# Altium schematic sheet 8.RELAY.SchDoc
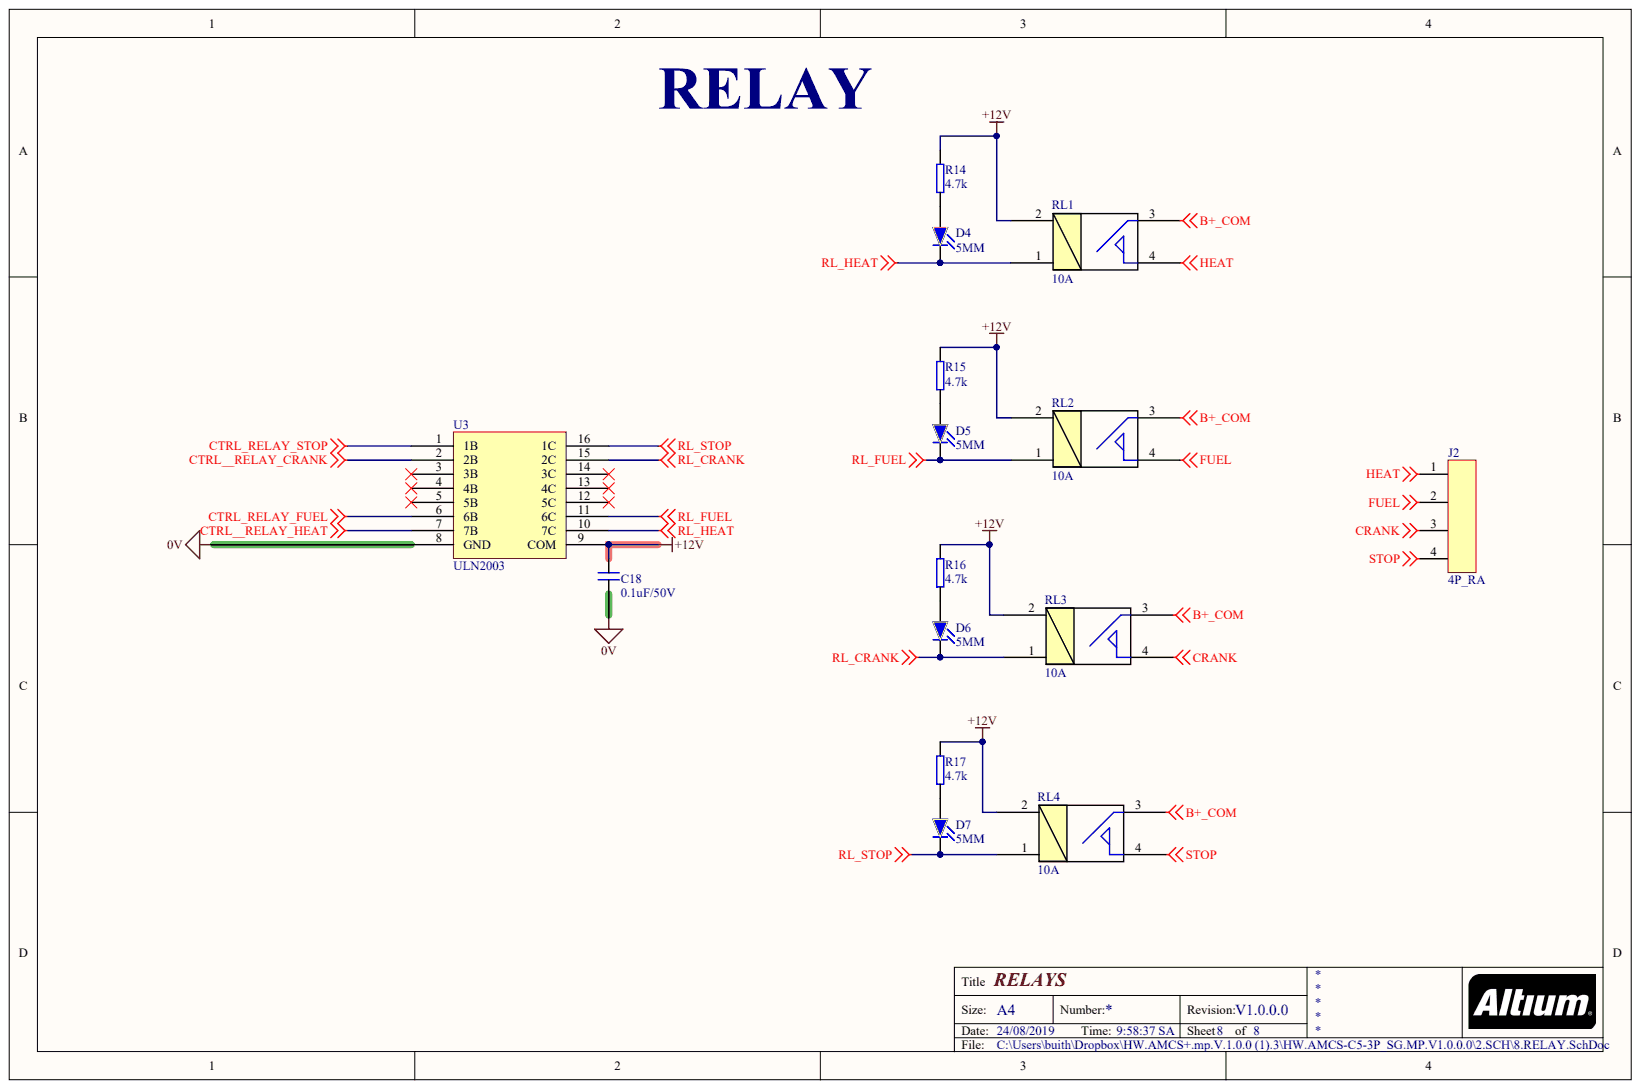
[diagram p0: 8.RELAY.SchDoc]

## Parts
C18  0.1uF/50V  [0805]
D4  5MM  [LED_BLUE_5mm]
D5  5MM  [LED_BLUE_5mm]
D6  5MM  [LED_BLUE_5mm]
D7  5MM  [LED_BLUE_5mm]
J2  4P_RA  [CONN_4x1_5.08_RA]
R14  4.7k  [0805]
R15  4.7k  [0805]
R16  4.7k  [0805]
R17  4.7k  [0805]
RL1  10A  [RELAY_SPST]
RL2  10A  [RELAY_SPST]
RL3  10A  [RELAY_SPST]
RL4  10A  [RELAY_SPST]
U3  ULN2003  [SOIC-16]

## Nets
0V: C18.2 U3.8(GND)
+12V: C18.1 R14.2 R15.2 R16.2 R17.2 RL1.2 RL2.2 RL3.2 RL4.2 U3.9(COM)
B+_COM: RL1.3 RL2.3 RL3.3 RL4.3
CRANK: J2.3 RL3.4
CTRL__RELAY_CRANK: U3.2(2B)
CTRL__RELAY_HEAT: U3.7(7B)
CTRL_RELAY_FUEL: U3.6(6B)
CTRL_RELAY_STOP: U3.1(1B)
FUEL: J2.2 RL2.4
HEAT: J2.1 RL1.4
RL_CRANK: D6.2 RL3.1 U3.15(2C)
RL_FUEL: D5.2 RL2.1 U3.11(6C)
RL_HEAT: D4.2 RL1.1 U3.10(7C)
RL_STOP: D7.2 RL4.1 U3.16(1C)
STOP: J2.4 RL4.4
NetD4_1: D4.1 R14.1
NetD5_1: D5.1 R15.1
NetD6_1: D6.1 R16.1
NetD7_1: D7.1 R17.1

Not connected: U3 3(3B) 4(4B) 5(5B) 12(5C) 13(4C) 14(3C)

## Library data of the parts
C18 = Cap_0.1uF: CAP CER 0.1UF 50V Y5V 0805; MFR: Yageo; Part Number: CC0805ZRY5V9BB104; AMCS CODE: VT-CAP-0004; ASS: SMT; Component Kind: Standard; Package: 0805; Library Name: Schlib_Diepvn_V01.SchLib
D4-D7 = LED_BLUE_5MM: LED 5MM HI EFF BLUE DIFF; MFR: Vishay Semiconductor Opto Division; ASS: TH; TYPE: DIO; Component Kind: Standard; LINK: http://linhkienchatluong.vn/led-don-f5-5mm/led-5mm-xanh-la-10c_sp697_ct1893.aspx; Package: Pitch:5mm; Library Name: Schlib_Diepvn_V01.SchLib
J2 = CONN_4x1_5.08_RA: 2EDGE 5.08MM 90 DEG; ASS: TH; Component Kind: Standard; LINK: http://linhkienchatluong.vn/cau-dau-ht508-xanh/cau-dau-4p-ht508-4p-508mm-xanh-cong_sp872_ct4433.aspx; LINK 1: https://banlinhkien.vn/goods-10588-con-4p-2edge-5-08mm-den-cong.html; Part Number: 2EDGE 5.08MM; TYPE: CONN; Library Name: Schlib_Diepvn_V01.SchLib
R14-R17 = Res_4.7k_0805: RES SMD 4.7K OHM 1% 1/8W 0805; MFR: Yageo; Part Number: RC0805FR-074K7L; AMCS CODE: VT-RES-0004; ASS: SMT; Component Kind: Standard; Package: 0805; TYPE: RES; Library Name: Schlib_Diepvn_V01.SchLib
RL1-RL4 = RELAY_OJE-SS-112HM2: RELAY GEN PURPOSE SPST 10A 12V; MFR: TE Connectivity Potter & Brumfield Relays; ASS: TH; Coil Current: 37.5mA; Coil Power: 450mW; Coil Resistance: 320 Ohms; Switching Voltage: 277VAC, 30VDC - Max; Component Kind: Standard; Package: SPST-NO (1 Form A); PART NUMBER: OJE-SS-112HM2; Library Name: Schlib_Diepvn_V01.SchLib
U3 = ULN2003A: IC PWR RELAY 7NPN 1:1 16SO; MFR: ST; Part Number: ULN2003D1013TR; Component Kind: Standard; Package: SOIC-16 (3.9mm); Supplier 1: Newark; Supplier Part Number 1: 09F1868; Library Name: Schlib_Diepvn_V01.SchLib
